# Altium schematic sheet POWER.SchDoc(POWER)
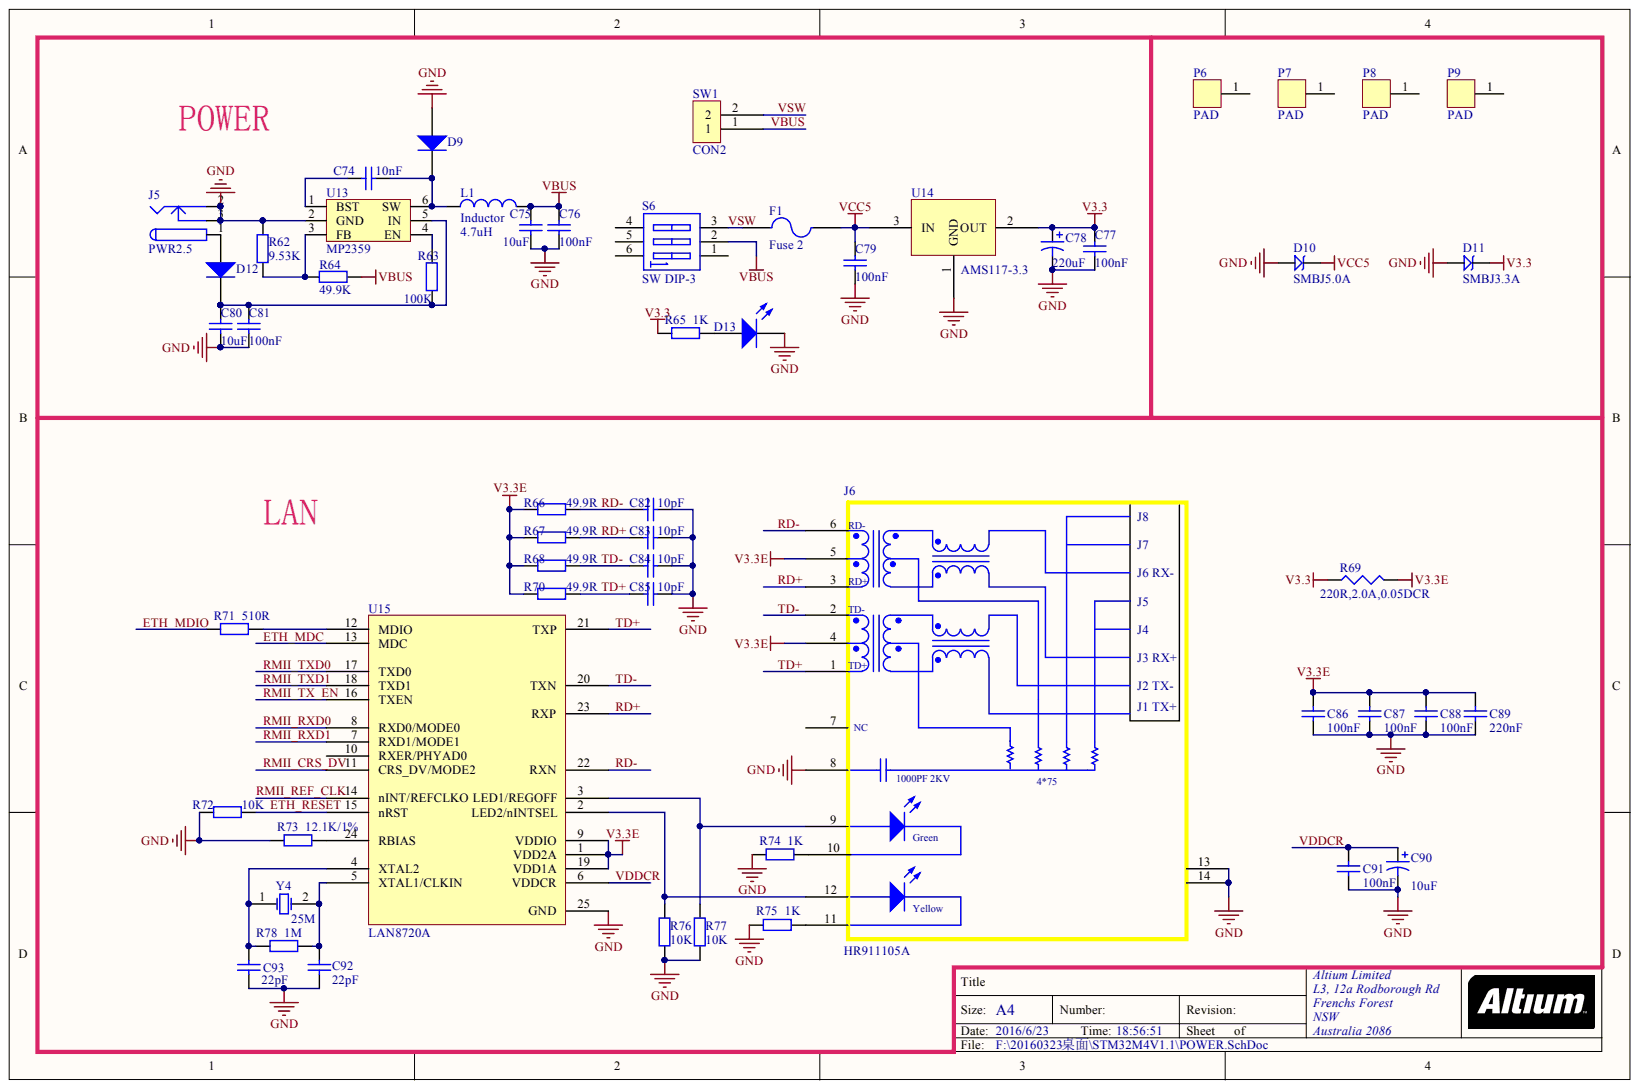
[diagram p0: POWER.SchDoc]

## Parts
C74  value 10nF  [0603]  (hidden comment: Cap)
C75  value 10uF  [CAP-6.5*6.5-SOP]  (hidden comment: Cap)
C76  value 100nF  [0603]  (hidden comment: Cap)
C77  value 100nF  [0603]  (hidden comment: Cap)
C78  value 220uF  [CAP-6.5*6.5-SOP]  (hidden comment: Cap Pol1)
C79  value 100nF  [0603]  (hidden comment: Cap)
C80  value 10uF  [0805]  (hidden comment: Cap)
C81  value 100nF  [0603]  (hidden comment: Cap)
C82  value 10pF  [0603]  (hidden comment: Cap)
C83  value 10pF  [0603]  (hidden comment: Cap)
C84  value 10pF  [0603]  (hidden comment: Cap)
C85  value 10pF  [0603]  (hidden comment: Cap)
C86  value 100nF  [0603]  (hidden comment: Cap)
C87  value 100nF  [0603]  (hidden comment: Cap)
C88  value 100nF  [0603]  (hidden comment: Cap)
C89  value 220nF  [0603]  (hidden comment: Cap)
C90  value 10uF  [0805]  (hidden comment: Cap Pol3)
C91  value 100nF  [0603]  (hidden comment: Cap)
C92  value 22pF  [0603]  (hidden comment: Cap)
C93  value 22pF  [0603]  (hidden comment: Cap)
D9  Diode  [SS14]  (value not drawn)
D10  SMBJ5.0A  [SMBJ]
D11  SMBJ3.3A  [SMBJ]
D12  Diode  [SS14]  (value not drawn)
D13  LED0  [LED]  (value not drawn)
F1  Fuse 2  [0805]
J5  PWR2.5  [DC-POWER]
J6  HR911105A  [HR911105A]
L1  Inductor  value 4.7uH  [L_SOP74]
P6  PAD  [PAD-120]
P7  PAD  [PAD-120]
P8  PAD  [PAD-120]
P9  PAD  [PAD-120]
R62  value 9.53K  [0603]  (hidden comment: Res2)
R63  value 100K  [0603]  (hidden comment: Res2)
R64  value 49.9K  [0603]  (hidden comment: Res2)
R65  value 1K  [0603]  (hidden comment: Res2)
R66  value 49.9R  [0603]  (hidden comment: Res2)
R67  value 49.9R  [0603]  (hidden comment: Res2)
R68  value 49.9R  [0603]  (hidden comment: Res2)
R69  value 220R,2.0A,0.05DCR  [0603]  (hidden comment: Res3)
R70  value 49.9R  [0603]  (hidden comment: Res2)
R71  value 510R  [0603]  (hidden comment: Res2)
R72  value 10K  [0603]  (hidden comment: Res2)
R73  value 12.1K/1%  [0603]  (hidden comment: Res2)
R74  value 1K  [0603]  (hidden comment: Res2)
R75  value 1K  [0603]  (hidden comment: Res2)
R76  value 10K  [0603]  (hidden comment: Res2)
R77  value 10K  [0603]  (hidden comment: Res2)
R78  value 1M  [0603]  (hidden comment: Res2)
S6  SW DIP-3  [SW-DC]
SW1  CON2  [CON2]
U13  MP2359  [SOT-23-6]
U14  AMS117-3.3  [SOT-223]
U15  LAN8720A  [LCC24]
Y4  value 25M  [XTAL]  (hidden comment: XTAL)

## Nets
GND: C75.2 C76.2 C77.2 C78.2 C79.2 C80.2 C81.2 C82.2 C83.2 C84.2 C85.2 C86.2 C87.2 C88.2 C89.2 C90.2 C91.2 C92.1 C93.1 D9.1 D10.1 D11.1 D13.2 J5.2 J5.3 J6.8 J6.13 J6.14 R62.1 R72.2 R73.2 R74.2 R75.2 R76.2 R77.2 U13.2(GND) U14.1 U15.25(GND)
V3.3: C77.1 C78.1 D11.2 R65.2 R69.1 U14.2
V3.3E: C86.1 C87.1 C88.1 C89.1 J6.4 J6.5 R66.2 R67.2 R68.2 R69.2 R70.2 U15.1(VDD2A) U15.9(VDDIO) U15.19(VDD1A)
VCC5: C79.1 D10.2 F1.2 U14.3
ETH_MDC: U15.13(MDC)
ETH_MDIO: R71.2
ETH_RESET: R72.1 U15.15(nRST)
RD+: C83.1 J6.3 R67.1 U15.23(RXP)
RD-: C82.1 J6.6 R66.1 U15.22(RXN)
RMII_CRS_DV: U15.11(CRS_DV/MODE2)
RMII_REF_CLK: U15.14(nINT/REFCLKO)
RMII_RXD0: U15.8(RXD0/MODE0)
RMII_RXD1: U15.7(RXD1/MODE1)
RMII_TX_EN: U15.16(TXEN)
RMII_TXD0: U15.17(TXD0)
RMII_TXD1: U15.18(TXD1)
TD+: C85.1 J6.1 R70.1 U15.21(TXP)
TD-: C84.1 J6.2 R68.1 U15.20(TXN)
VBUS: C75.1 C76.1 L1.2 R64.2 S6.2 SW1.1
VDDCR: C90.1 C91.1 U15.6(VDDCR)
VSW: F1.1 S6.3 SW1.2
NetC74_1: C74.1 U13.1(BST)
NetC74_2: C74.2 D9.2 L1.1 U13.6(SW)
NetC80_1: C80.1 C81.1 D12.2 R63.2 U13.5(IN)
NetC92_2: C92.2 R78.2 U15.5(XTAL1/CLKIN) Y4.2
NetC93_2: C93.2 R78.1 U15.4(XTAL2) Y4.1
NetD12_1: D12.1 J5.1
NetD13_1: D13.1 R65.1
NetJ6_9: J6.9 R77.1 U15.3(LED1/REGOFF)
NetJ6_10: J6.10 R74.1
NetJ6_11: J6.11 R75.1
NetJ6_12: J6.12 R76.1 U15.2(LED2/nINTSEL)
NetR62_2: R62.2 R64.1 U13.3(FB)
NetR63_1: R63.1 U13.4(EN)
NetR71_1: R71.1 U15.12(MDIO)
NetR73_1: R73.1 U15.24(RBIAS)

Not connected: J6 7(NC); P6 1; P7 1; P8 1; P9 1; S6 1 4 5 6; U15 10(RXER/PHYAD0)

## Library data of the parts
C74-C77 C79-C89 C91-C93 = Cap: Capacitor; Component Kind: Standard; LatestRevisionDate: 17-Jul-2002; LatestRevisionNote: Re-released for DXP Platform.; Library Name: Miscellaneous Devices.IntLib; PackageDescription: Radial Cap, Thru-Hole; 2 Leads; 0.3 in Pin Spacing; PackageReference: RAD-0.3; Published: 8-Jun-2000; Publisher: Altium Limited
  C74 C76 C77 C79-C89 C91-C93: Signal Integrity: Cap; Simulation: CAP
C78 = Cap Pol1: Polarized Capacitor (Radial); Component Kind: Standard; LatestRevisionDate: 17-Jul-2002; LatestRevisionNote: Re-released for DXP Platform.; Library Name: Miscellaneous Devices.IntLib; PackageDescription: Polarized Capacitor (Radial); 2 Leads; PackageReference: RB7.6-15; Published: 8-Jun-2000; Publisher: Altium Limited
C90 = Cap Pol3: Polarized Capacitor (Surface Mount); Component Kind: Standard; LatestRevisionDate: 29-May-2009; LatestRevisionNote: IPC-7351 Footprint Added.; Library Name: Miscellaneous Devices.IntLib; PackageDescription: Chip Capacitor; PackageReference: C0805; Published: 8-Jun-2000; Signal Integrity: Cap Pol; Simulation: CAP; Publisher: Altium Limited
D9 D12 = Diode: Default Diode; Code_JEDEC: DO-214-AB; Component Kind: Standard; LatestRevisionDate: 17-Jul-2002; LatestRevisionNote: Re-released for DXP Platform.; Library Name: Miscellaneous Devices.IntLib; PackageDescription: DO-214-AB/SMC; 2 C-Bend Leads; Body 7.9 x 5.9 mm, inc. leads (LxW); PackageReference: SMC; PackageVersion: Sep-1996; Published: 8-Jun-2000; Signal Integrity: Diode; Simulation: DIODE; Publisher: Altium Limited
D10 D11 = SMBJ: TVS; Component Kind: Standard; Library Name: M4.SCHLIB
D13 = LED0: Typical INFRARED GaAs LED; Component Kind: Standard; LatestRevisionDate: 08-Jul-2005; LatestRevisionNote: Mech Layer 1 removed.; Library Name: Miscellaneous Devices.IntLib; PackageDescription: LED; 2 Leads; PackageReference: LED-0; Published: 8-Jun-2000; Publisher: Altium Limited
F1 = Fuse 2: Fuse; Component Kind: Standard; LatestRevisionDate: 17-Jul-2002; LatestRevisionNote: Re-released for DXP Platform.; Library Name: Miscellaneous Devices.IntLib; PackageDescription: Thru-Hole; 2 Leads; PackageReference: PIN-W2/E2.8; Published: 8-Jun-2000; Publisher: Altium Limited
J5 = PWR2.5: Low Voltage Power Supply Connector; Component Kind: Standard; ComponentLink1Description: Manufacturer Link; ComponentLink1URL: http://domino.kycon.com/internet/Products.html; DatasheetDocument: 09-May-2002; Library Name: MyLibrary.SchLib; Published: 17-Dec-2003; Publisher: Altium Limited
L1 = Inductor: Code_IEC: 1005; Code_JEITA: 0402; Component Kind: Standard; Description: Inductor; LatestRevisionDate: 29-May-2009; LatestRevisionNote: IPC-7351 Footprint Added.; Library Name: Miscellaneous Devices.IntLib; PackageDescription: Chip Inductor; PackageReference: 0402-A; Published: 8-Jun-2000; Publisher: Altium Limited
R62-R68 R70-R78 = Res2: Resistor; Component Kind: Standard; LatestRevisionDate: 17-Jul-2002; LatestRevisionNote: Re-released for DXP Platform.; Library Name: Miscellaneous Devices.IntLib; PackageDescription: Axial Device, Thru-Hole; 2 Leads; 0.4 in Pin Spacing; PackageReference: AXIAL-0.4; Published: 8-Jun-2000; Signal Integrity: Res; Simulation: RESISTOR; Publisher: Altium Limited
R69 = Res3: Resistor; Component Kind: Standard; LatestRevisionDate: 29-May-2009; LatestRevisionNote: IPC-7351 Footprint Added.; Library Name: Miscellaneous Devices.IntLib; PackageDescription: Chip Resistor; PackageReference: J1-0603; Published: 8-Jun-2000; Publisher: Altium Limited
S6 = SW DIP-3: DIP Switch, 3 Position, SPST; Code_JEDEC: MO-001-AM; Component Kind: Standard; LatestRevisionDate: 17-Jul-2002; LatestRevisionNote: Re-released for DXP Platform.; Library Name: MyLibrary.SchLib; PackageReference: DIP-6; Published: 24-Mar-1999; Publisher: Altium Limited
U13 = MP2359: DC DC Step Down IC; Component Kind: Standard; Library Name: MyLibrary.IntLib
U14 = AMS117: 稳压芯片; Component Kind: Standard; Library Name: MyLibrary.IntLib
U15 = LAN8720A: Small?Footprint?RMII?10/100?Ethernet?Transceiver?with?HP?Auto-MDIX?Support; Component Kind: Standard; Library Name: MyLibrary.IntLib
Y4 = XTAL: Crystal Oscillator; Component Kind: Standard; LatestRevisionDate: 17-Jul-2002; LatestRevisionNote: Re-released for DXP Platform.; Library Name: Miscellaneous Devices.IntLib; PackageDescription: Crystal, Thru-Hole; 2 Leads; Body 3.1 x 8.2 mm (Dia.xH); PackageReference: R38; Published: 8-Jun-2000; Simulation: XTAL; Publisher: Altium Limited
J6 = HR911105A: Component Kind: Standard; Library Name: MyLibrary.SchLib
P6-P9 = PAD: Component Kind: Standard; Library Name: MyLibrary.IntLib
SW1 = CON2: Component Kind: Standard
